# Altium schematic sheet 01)Power_Supply.SchDoc(01)Power_Supply)
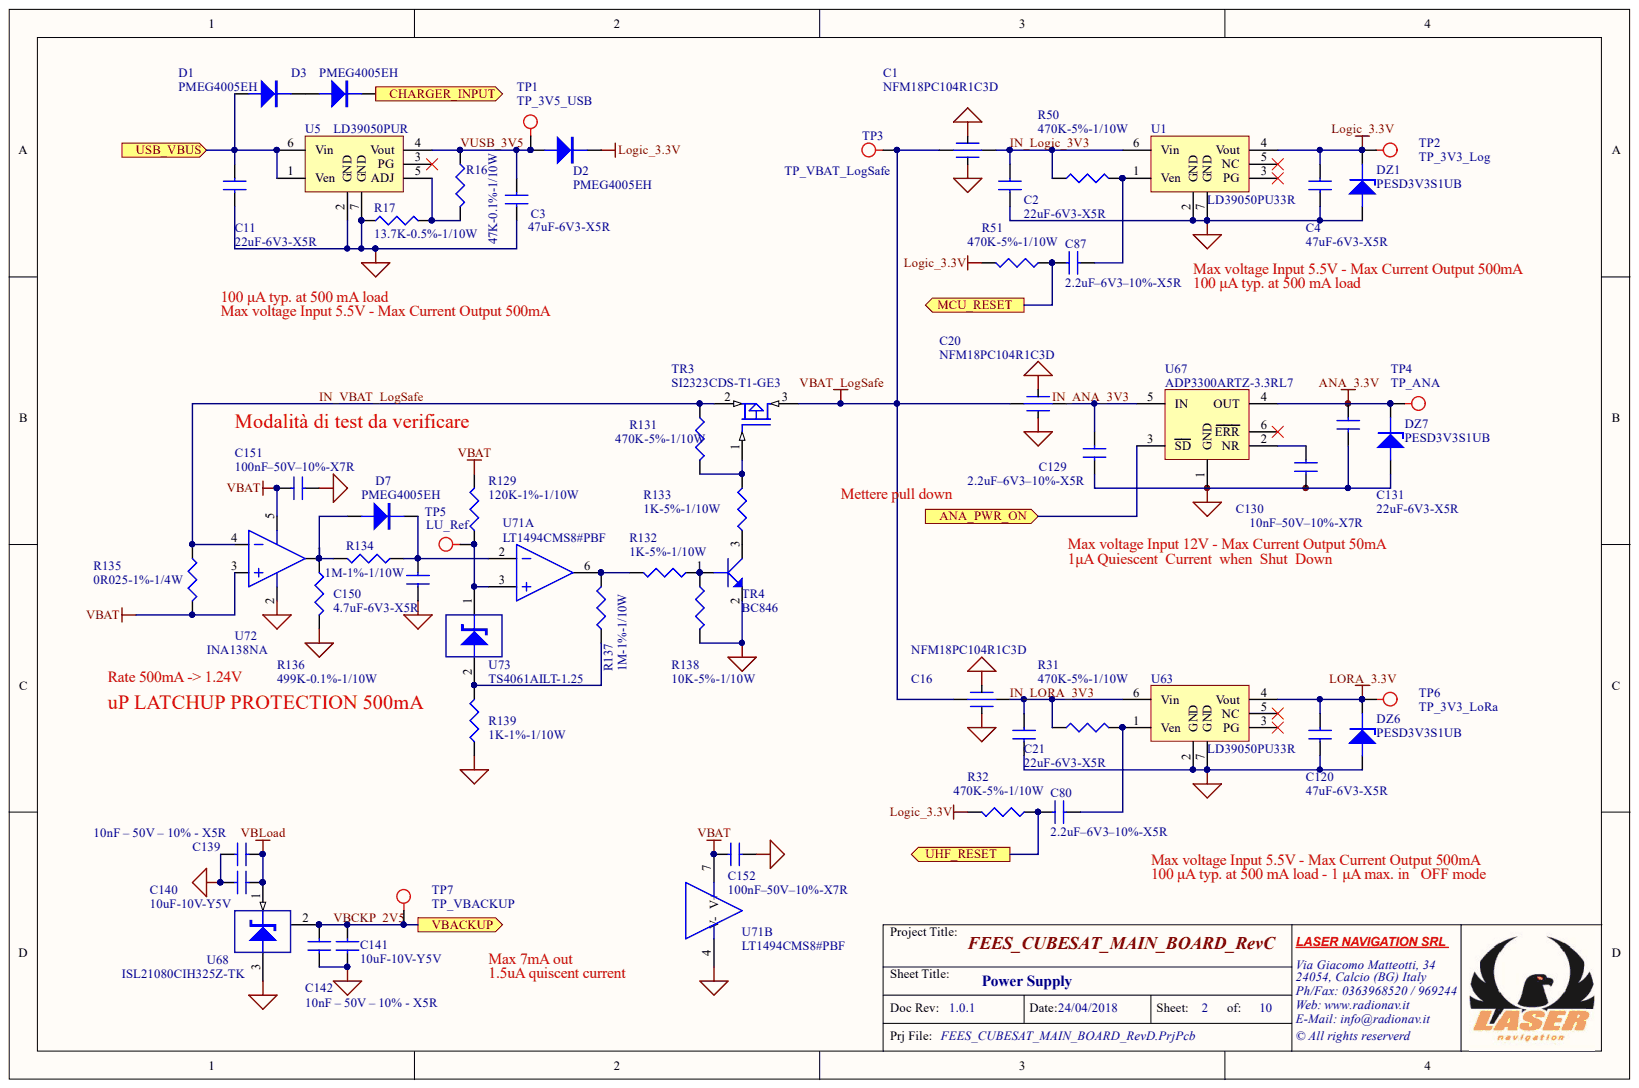
[diagram p0: 01)Power_Supply.SchDoc]

## Parts
C1  NFM18PC104R1C3D  [0603_EMI_DA]
C2  22uF-6V3-X5R  [0805_CAP]
C3  47uF-6V3-X5R  [0805_CAP]
C4  47uF-6V3-X5R  [0805_CAP]
C11  22uF-6V3-X5R  [0805_CAP]
C16  NFM18PC104R1C3D  [0603_EMI_DA]
C20  NFM18PC104R1C3D  [0603_EMI_DA]
C21  22uF-6V3-X5R  [0805_CAP]
C80  2.2uF–6V3–10%-X5R  [0603_CAP]
C87  2.2uF–6V3–10%-X5R  [0603_CAP]
C120  47uF-6V3-X5R  [0805_CAP]
C129  2.2uF–6V3–10%-X5R  [0603_CAP]
C130  10nF–50V–10%-X7R  [0603_CAP]
C131  22uF-6V3-X5R  [0805_CAP]
C139  10nF – 50V – 10% - X5R  [0603_CAP]
C140  10uF-10V-Y5V  [0805_CAP]
C141  10uF-10V-Y5V  [0805_CAP]
C142  10nF – 50V – 10% - X5R  [0603_CAP]
C150  4.7uF-6V3-X5R  [0805_CAP]
C151  100nF–50V–10%-X7R  [0603_CAP]
C152  100nF–50V–10%-X7R  [0603_CAP]
D1  PMEG4005EH  [SOD123]
D2  PMEG4005EH  [SOD123]
D3  PMEG4005EH  [SOD123]
D7  PMEG4005EH  [SOD123]
DZ1  PESD3V3S1UB  [SOD523]
DZ6  PESD3V3S1UB  [SOD523]
DZ7  PESD3V3S1UB  [SOD523]
R16  47K-0.1%-1/10W  [0603_RES]
R17  13.7K-0.5%-1/10W  [0603_RES]
R31  470K-5%-1/10W  [0603_RES]
R32  470K-5%-1/10W  [0603_RES]
R50  470K-5%-1/10W  [0603_RES]
R51  470K-5%-1/10W  [0603_RES]
R129  120K-1%-1/10W  [0603_RES]
R131  470K-5%-1/10W  [0603_RES]
R132  1K-5%-1/10W  [0603_RES]
R133  1K-5%-1/10W  [0603_RES]
R134  1M-1%-1/10W  [0603_RES]
R135  0R025-1%-1/4W  [0805_RES]
R136  499K-0.1%-1/10W  [0603_RES]
R137  1M-1%-1/10W  [0603_RES]
R138  10K-5%-1/10W  [0603_RES]
R139  1K-1%-1/10W  [0603_RES]
TP1  TP_3V5_USB  [TP_SMD_PAD_1_5MM]
TP2  TP_3V3_Log  [TP_SMD_PAD_1_5MM]
TP3  TP_VBAT_LogSafe  [TP_SMD_PAD_1_5MM]
TP4  TP_ANA  [TP_SMD_PAD_1_5MM]
TP5  LU_Ref  [TP_SMD_PAD_1_5MM]
TP6  TP_3V3_LoRa  [TP_SMD_PAD_1_5MM]
TP7  TP_VBACKUP  [TP_SMD_PAD_1_5MM]
TR3  SI2323CDS-T1-GE3  [SOT23]
TR4  BC846  [BC_SOT32]
U1  LD39050PU33R  [DFN6_3X3_095]
U5  LD39050PUR  [DFN6_3X3_095]
U63  LD39050PU33R  [DFN6_3X3_095]
U67  ADP3300ARTZ-3.3RL7  [SOT23-6]
U68  ISL21080CIH325Z-TK  [SOT23]
U71  LT1494CMS8#PBF  [MSOP8]  (parts A,B)
U72  INA138NA  [SOT23-5]
U73  TS4061AILT-1.25  [SOT23]

## Ports
ANA_PWR_ON, CHARGER_INPUT, MCU_RESET, UHF_RESET, USB_VBUS, VBACKUP

## Nets
ANA_3.3V: C131.1 DZ7.2 TP4.1 U67.4(OUT)
GND: C1.3 C1.4 C2.2 C3.2 C4.2 C11.2 C16.3 C16.4 C20.3 C20.4 C21.2 C120.2 C129.2 C130.2 C131.2 C139.2 C140.1 C141.1 C142.2 C150.1 C151.2 C152.1 DZ1.1 DZ6.1 DZ7.1 R17.1 R136.2 R138.2 R139.1 TR4.2 U1.2(GND) U1.7(GND) U5.2(GND) U5.7(GND) U63.2(GND) U63.7(GND) U67.1(GND) U68.3 U71.4(V-) U72.2
LOGIC_3.3V: C4.1 D2.2 DZ1.2 R32.2 R51.2 TP2.1 U1.4(Vout)
LORA_3.3V: C120.1 DZ6.2 TP6.1 U63.4(Vout)
VBAT: C151.1 C152.2 R129.2 R135.2 U71.7(V+) U72.3 U72.5
VBAT_LOGSAFE: C1.1 C16.1 C20.1 TP3.1 TR3.3
VBLOAD: C139.1 C140.2 U68.1
ANA_PWR_ON: U67.3(SD) ; port ANA_PWR_ON
CHARGER_INPUT: D3.2 ; port CHARGER_INPUT
IN_LOGIC_3V3: C1.2 C2.1 R50.1 U1.6(Vin)
IN_LORA_3V3: C16.2 C21.1 R31.1 U63.6(Vin)
IN_VBAT_LOGSAFE: R131.1 R135.1 TR3.2 U72.4
MCU_RESET: C87.1 R51.1 ; port MCU_RESET
UHF_RESET: C80.1 R32.1 ; port UHF_RESET
USB_VBUS: C11.1 D1.1 U5.1(Ven) U5.6(Vin) ; port USB_VBUS
VBCKP_2V5: C141.2 C142.1 TP7.1 U68.2 ; port VBACKUP
VUSB_3V5: C3.1 D2.1 R16.1 TP1.1 U5.4(Vout)
NetC20_2: C20.2 C129.1 U67.5(IN)
NetC80_2: C80.2 R31.2 U63.1(Ven)
NetC87_2: C87.2 R50.2 U1.1(Ven)
NetC130_1: C130.1 U67.2(NR)
NetC150_2: C150.2 D7.2 R134.1 U71.2
NetD1_2: D1.2 D3.1
NetD7_1: D7.1 R134.2 R136.1 U72.1
NetR16_2: R16.2 R17.2 U5.5(ADJ)
NetR129_1: R129.1 TP5.1 U71.3 U73.1
NetR131_2: R131.2 R133.2 TR3.1
NetR132_1: R132.1 R138.1 TR4.1
NetR132_2: R132.2 R137.2 U71.6
NetR133_1: R133.1 TR4.3
NetR137_1: R137.1 R139.2 U73.2
IN_ANA_3V3:

Not connected: U1 3(PG) 5(NC); U5 3(PG); U63 3(PG) 5(NC); U67 6(ERR)

## Library data of the parts
C1 C16 C20 = CAP_EMI: Capacitor; 2.Manufacturer: Murata; 3.Description: 0.1µF Feed Through Capacitor 16V 2A 30 mOhm 0603 (1608 Metric), 3 PC Pad; 5.Mounting: Y; 1.Part Number: NFM18PC104R1C3D; 4.Supplier: Digikey  490-6969-1-ND; Component Kind: Standard; Library Name: User Sch Library.SchLib
C2 C11 C21 C131 = CAP: Non polarized capacitor; 1.Part Number: CC0805MKX5R5BB226; 2.Manufacturer: Yageo; 3.Description: CAP CER 22UF 6.3V X5R 0805; 5.Mounting: Y; 4.Supplier: Digikey 	311-1464-1-ND; Component Kind: Standard; Library Name: User Sch Library.SchLib
C3 C4 C120 = CAP: Non polarized capacitor; 1.Part Number: JMK212BJ476MG-T; 2.Manufacturer: Taiyo Yuden; 3.Description: CAP CER 47UF 6.3V X5R 0805; 5.Mounting: Y; 4.Supplier: Digikey 587-1779-1-ND; Component Kind: Standard; Library Name: User Sch Library.SchLib
C80 C87 C129 = CAP: Non polarized capacitor; 1.Part Number: JMK107BJ225KAHT; 2.Manufacturer: Taiyo Yuden; 3.Description: CAP CER 2.2UF 6.3V X5R 0603; 5.Mounting: Y; 4.Supplier: Digikey 587-3386-1-ND; Component Kind: Standard; Library Name: User Sch Library.SchLib
C130 C151 C152 = CAP: Non polarized capacitor; Component Kind: Standard; Library Name: User Sch Library.SchLib
C139 C142 = CAP: Non polarized capacitor; 5.Mounting: Y; Component Kind: Standard; Library Name: User Sch Library.SchLib
C140 C141 = CAP: Non polarized capacitor; 1.Part Number: C0805C106Z8VACTU; 2.Manufacturer: Kemet; 3.Description: CAP CER 10UF 10V -20%/+80% Y5V 0805; 5.Mounting: Y; 4.Supplier: Mouser 80-C0805C106Z8V  // Digikey 399-8015-1-ND; Component Kind: Standard; Library Name: User Sch Library.SchLib
C150 = CAP: Non polarized capacitor; 1.Part Number: CC0805KRX5R5BB475; 2.Manufacturer: Yageo; 3.Description: CAP CER 4.7UF 6.3V X5R 0805; 5.Mounting: Y; 4.Supplier: Digikey 311-3422-1-ND; Component Kind: Standard; Library Name: User Sch Library.SchLib
D1-D3 D7 = DIODE_SS34: Diode; 1.Part Number: PMEG4005EH,115; 2.Manufacturer: NXP Semiconductors; 3.Description: IODE SCHOTTKY 40V 500MA SOD123F; 5.Mounting: Y; 4.Supplier: Farnell 1510688RL // Digikey 568-4132-1-ND; Component Kind: Standard; Library Name: User Sch Library.SchLib
DZ1 DZ6 DZ7 = ZENER4: Zener Diode; 1.Part Number: PESD3V3S1UB,115; 2.Manufacturer: NXP Semiconductors; 3.Description: TVS DIODE 3.3VWM 20VC SOD523; 5.Mounting: Y; 4.Supplier: Digikey 568-4044-1-ND; Component Kind: Standard; Library Name: User Sch Library.SchLib
R16 = R: 1.Part Number: ERJ-PB3B4702V; 2.Manufacturer: Panasonic Electronic Components; 3.Description: RES SMD 47K OHM 0.1% 1/5W 0603; 5.Mounting: Y; 4.Supplier: Digikey P20162CT-ND; Component Kind: Standard; Library Name: User Sch Library.SchLib
R17 = R: 1.Part Number: RT0603DRE0713K7L; 2.Manufacturer: Yageo; 3.Description: RES SMD 13.7KOHM 0.5% 1/10W 0603; 5.Mounting: Y; 4.Supplier: Digikey 311-2421-1-ND; Component Kind: Standard; Library Name: User Sch Library.SchLib
R31 R32 R50 R51 R129 R131-R133 R139 = R: Component Kind: Standard; Library Name: User Sch Library.SchLib
R134 R137 R138 = R: 5.Mounting: Y; Component Kind: Standard; Library Name: User Sch Library.SchLib
R135 = R: 1.Part Number: WSL0805R0250FEA18; 2.Manufacturer: Vishay Dale; 3.Description: RES SMD 0.025 OHM 1% 1/4W 0805; 5.Mounting: Y; 4.Supplier: Digikey WSLB-.025CT-ND; Component Kind: Standard; Library Name: User Sch Library.SchLib
R136 = R: 1.Part Number: RT0603BRE07499KL; 2.Manufacturer: Yageo; 3.Description: RES SMD 499K OHM 0.1% 1/10W 0603; 5.Mounting: Y; 4.Supplier: Digikey YAG4738CT-ND; Component Kind: Standard; Library Name: User Sch Library.SchLib
TP1-TP7 = TP: Test Point; Component Kind: Standard; Library Name: User Sch Library.SchLib
TR3 = MOSFET P_1: 2.Manufacturer: Vishay Siliconix; 3.Description: MOSFET P-CH 20V 6A SOT-23; 5.Mounting: Y; 1.Part Number: SI2323CDS-T1-GE3; 4.Supplier: Digikey  SI2323CDS-T1-GE3CT-ND; Component Kind: Standard; Library Name: User Sch Library.SchLib
TR4 = BJT_NPN: NPN Bipolar Transistor; 1.Part Number: BC846B,215; 2.Manufacturer: NXP Semiconductors; 3.Description: TRANS NPN 65V 0.1A SOT23; 5.Mounting: Y; 4.Supplier: Mouser 771-BC846B-T/R  // Digikey 568-1632-1-ND; Component Kind: Standard; Library Name: User Sch Library.SchLib
U1 U63 = LD39050: LDO; 2.Manufacturer: STMicroelectronics; 3.Description: Linear Voltage Regulator IC Positive Fixed 1 Output 3.3V 500mA 6-DFN (3x3); 5.Mounting: Y; 1.Part Number: LD39050PU33R; 4.Supplier: Digikey 497-8716-1-ND; Component Kind: Standard; Library Name: User Sch Library.SchLib
U5 = LD39050PUR: LDO; 2.Manufacturer: STMicroelectronics; 3.Description: IC REG LDO ADJ 0.5A 6DFN; 5.Mounting: Y; 1.Part Number: LD39050PUR; 4.Supplier: Digikey 497-8717-1-ND; Component Kind: Standard; Library Name: User Sch Library.SchLib
U67 = ADP3300: Low quiescient corrent High Accuracy LDO; 2.Manufacturer: Analog Devices Inc.; 3.Description: Linear Voltage Regulator IC Positive Fixed 1 Output 3.3V 50mA SOT-23-6; 5.Mounting: Y; 1.Part Number: ADP3300ARTZ-3.3RL7; 4.Supplier: Digikey ADP3300ARTZ3.3RCT-ND; Component Kind: Standard; Library Name: User Sch Library.SchLib
U68 = ISL21080: Voltage Reference; 2.Manufacturer: Intersil; 3.Description: Series Voltage Reference IC ±0.3% 7mA SOT-23-3; 5.Mounting: Y; 1.Part Number: ISL21080CIH325Z-TK; 4.Supplier: Digikey ISL21080CIH325Z-TKCT-ND; Component Kind: Standard; Library Name: User Sch Library.SchLib
U71 = LT1494: Low power dual operational amplifiers; 2.Manufacturer: Linear Technology; 3.Description: General Purpose Amplifier 1 Circuit Rail-to-Rail 8-MSOP; 5.Mounting: Y; 1.Part Number: LT1494CMS8#PBF; 4.Supplier: Digikey LT1494CMS8#PBF-ND; Component Kind: Standard; Library Name: User Sch Library.SchLib
U72 = INA138NA: Operational Amplifier; 1.Part Number: INA138NA/3K; 2.Manufacturer: Texas Instruments; 3.Description: IC CURRENT MONITOR 0.5% SOT23-5; 5.Mounting: Y; 4.Supplier: Digikey  296-17936-1-ND; Component Kind: Standard; Library Name: User Sch Library.SchLib
U73 = TS4061: Voltage Reference; 2.Manufacturer: STMicroelectronics; 3.Description: Shunt Voltage Reference IC ±0.1% 15mA SOT-23-3; 5.Mounting: Y; 1.Part Number: TS4061AILT-1.25; 4.Supplier: Digikey 497-15222-1-ND; Component Kind: Standard; Library Name: User Sch Library.SchLib
